# Altium schematic sheet Magnetometer.SchDoc(Magnetometer)
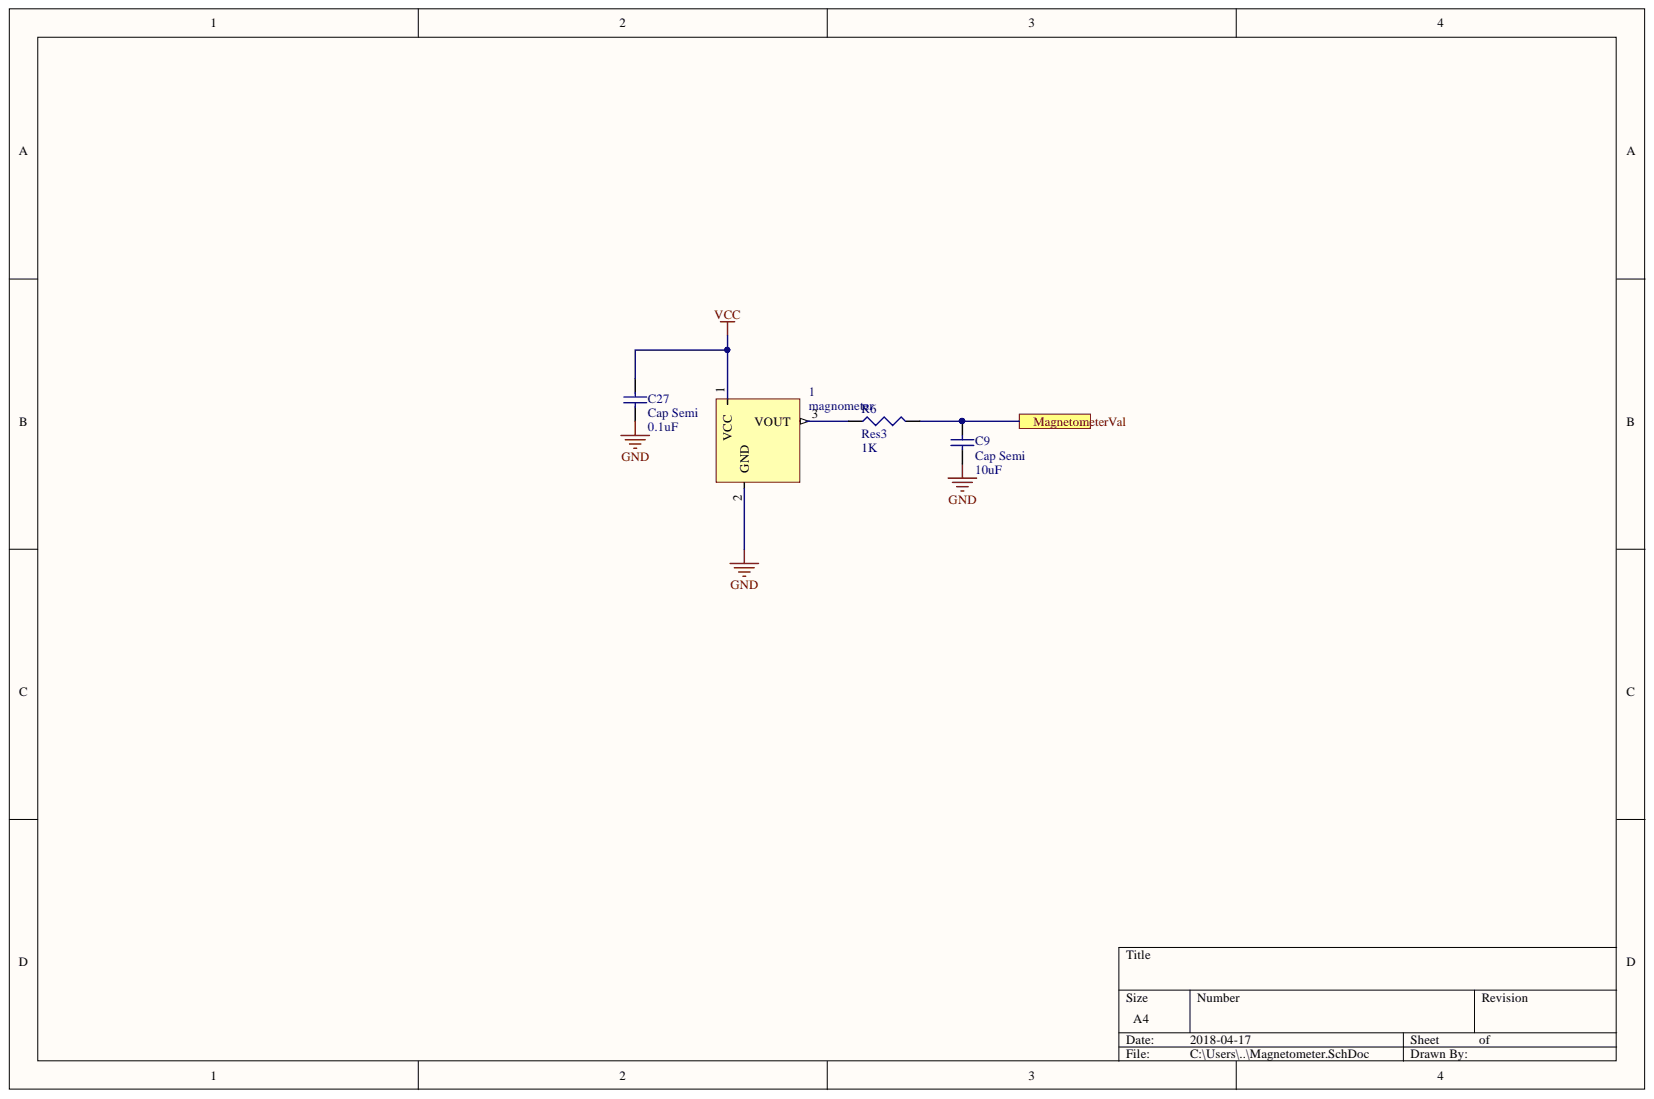
[diagram p0: Magnetometer.SchDoc]

## Parts
1  magnometer  [SIP-3]
C9  Cap Semi  [1608[0603]]  (value not drawn)
C27  Cap Semi  [1608[0603]]  (value not drawn)
R6  Res3  [J1-0603]  (value not drawn)

## Ports
MagnetometerVal

## Nets
GND: 1.2 C9.1 C27.1
VCC: 1.1 C27.2
MagnetometerVal: C9.2 R6.2 ; port MagnetometerVal
Net1_3: 1.3 R6.1

## Library data of the parts
C9 = Cap Semi: Capacitor (Semiconductor SIM Model); Value: 10uF; Simulation: CAP; Signal Integrity: Cap Semi; Published: 8-Jun-2000; PackageReference: C1206; PackageDescription: Chip Capacitor; Library Name: Miscellaneous Devices.IntLib; LatestRevisionNote: IPC-7351 Footprint Added.; LatestRevisionDate: 29-May-2009; Component Kind: Standard; Publisher: Altium Limited
C27 = Cap Semi: Capacitor (Semiconductor SIM Model); Value: 0.1uF; Simulation: CAP; Signal Integrity: Cap Semi; Published: 8-Jun-2000; PackageReference: C1206; PackageDescription: Chip Capacitor; Library Name: Miscellaneous Devices.IntLib; LatestRevisionNote: IPC-7351 Footprint Added.; LatestRevisionDate: 29-May-2009; Component Kind: Standard; Publisher: Altium Limited
R6 = Res3: Resistor; Value: 1K; Simulation: RESISTOR; Signal Integrity: Res; Published: 8-Jun-2000; PackageReference: J1-0603; PackageDescription: Chip Resistor; Library Name: Miscellaneous Devices.IntLib; LatestRevisionNote: IPC-7351 Footprint Added.; LatestRevisionDate: 29-May-2009; Component Kind: Standard; Publisher: Altium Limited
1 = magnometer: Component Kind: Standard; Library Name: HGCDLibrary.IntLib
